# Altium schematic sheet 3A04xx_Oxocard_Mini_Science_SENSORS.SchDoc
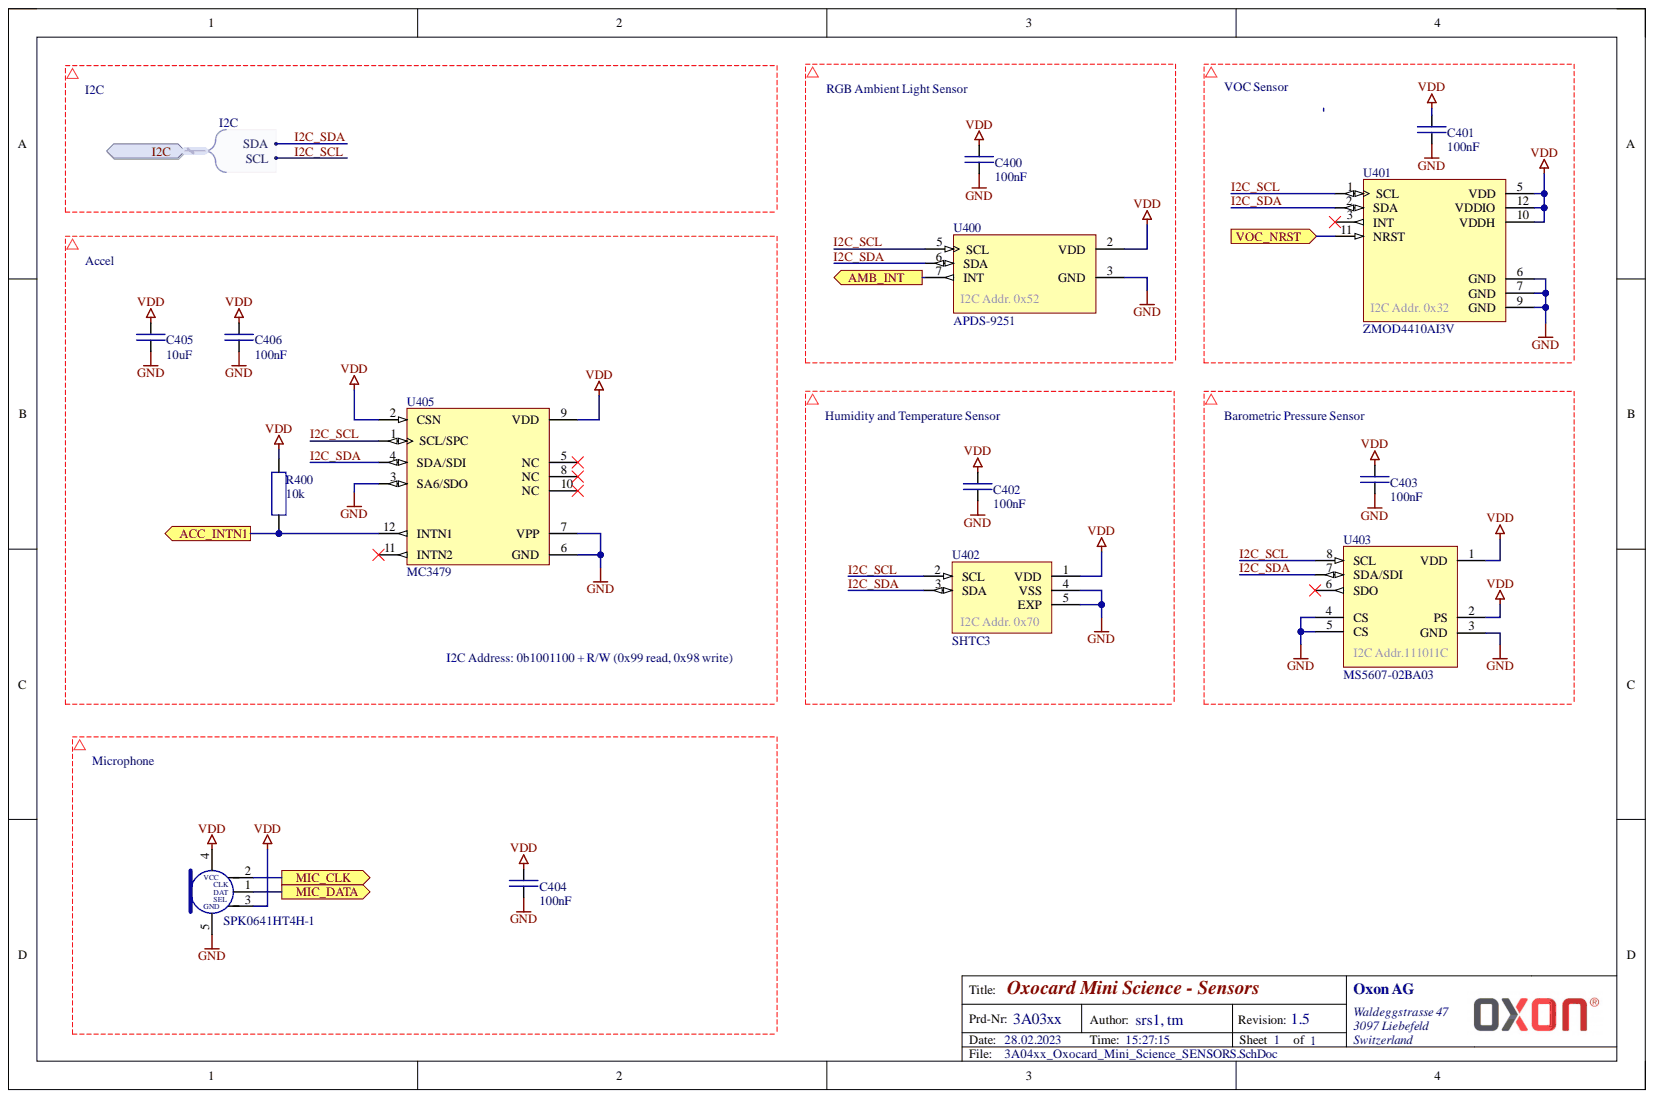
[diagram p0: 3A04xx_Oxocard_Mini_Science_SENSORS.SchDoc]

## Parts
C400  100nF  [CAPC1005X60]
C401  100nF  [CAPC1005X60]
C402  100nF  [CAPC1005X60]
C403  100nF  [CAPC1005X60]
C404  100nF  [CAPC1005X60]
C405  10uF  [CAPC1005X60]
C406  100nF  [CAPC1005X60]
R400  10k  [RESC1005X40]
SPK0641HT4H-1  Manufacturer Designator: SPK0641HT4H-1  [MIC-SPK0641HT4H-1]  (hidden comment: MK-SPK0641HT4H-1)
U400  APDS-9251  [SON50P200X65-8N]
U401  ZMOD4410AI3V  [LGA-12 3x3mm]
U402  SHTC3  [DFN170P200X75-4N]
U403  MS5607-02BA03  [SON125P300X95-8N]
U405  MC3479  [LGA-12]

## Ports
ACC_INTN1, AMB_INT, I2C, I2C.SCL, I2C.SDA, MIC_CLK, MIC_DATA, VOC_NRST

## Nets
GND: C400.1 C401.1 C402.1 C403.1 C404.1 C405.1 C406.1 SPK0641HT4H-1.5 U400.3(GND) U401.6(GND) U401.7(GND) U401.9(GND) U402.4(VSS) U402.5(EXP) U403.3(GND) U403.4(CS) U403.5(CS) U405.3(SA6/SDO) U405.6(GND) U405.7(VPP)
VDD: C400.2 C401.2 C402.2 C403.2 C404.2 C405.2 C406.2 R400.2 SPK0641HT4H-1.3(GND) SPK0641HT4H-1.4 U400.2(VDD) U401.5(VDD) U401.10(VDDH) U401.12(VDDIO) U402.1(VDD) U403.1(VDD) U403.2(PS) U405.2(CSN) U405.9(VDD)
ACC_INTN1: R400.1 U405.12(INTN1) ; port ACC_INTN1
AMB_INT: U400.7(INT) ; port AMB_INT
I2C_SCL: U400.5(SCL) U401.1(SCL) U402.2(SCL) U403.8(SCL) U405.1(SCL/SPC) ; port I2C
I2C_SDA: U400.6(SDA) U401.2(SDA) U402.3(SDA) U403.7(SDA/SDI) U405.4(SDA/SDI) ; port I2C
MIC_CLK: SPK0641HT4H-1.2(VCC) ; port MIC_CLK
MIC_DATA: SPK0641HT4H-1.1(DAT) ; port MIC_DATA
VOC_NRST: U401.11(NRST) ; port VOC_NRST

Not connected: U401 3(INT); U403 6(SDO); U405 5(NC) 8(NC) 10(NC) 11(INTN2)

## Library data of the parts
C400-C404 C406 = C-CAP0402_100n: SMD capacitor 10V 5%; Manufacturer: Wurth Electronics; Manufacturer Designator: GRM155R61A104JA1D; Component Kind: Standard; Distributor: Mouser; Distributor Part-Number: 81-GRM155R61A104JA1D; Library Name: XLib.SCHLIB
C405 = C-CAP0402_10u: SMD capacitor 6.3V 20%; Manufacturer: Wurth Electronics; Manufacturer Designator: ZRB15XR60J106ME2D; Component Kind: Standard; Distributor: Mouser; Distributor Part-Number: 81-ZRB15XR60J106ME2D; Library Name: XLib.SCHLIB
R400 = R-RES0402_10k: SMD resistor 1%; Manufacturer: Yageo; Manufacturer Designator: RC0402FR-0710KL; Component Kind: Standard; Distributor: Mouser; Distributor Part-Number: 603-RC0402FR-0710KL; Library Name: XLib.SCHLIB
SPK0641HT4H-1 = MK-SPK0641HT4H-1: MEMS-Mikrofone Top Port PDM SiSonic; Manufacturer: Knowles; Component Kind: Standard; Distributor: Mouser; Distributor Part-Number: 721-SPK0641HT4H-1; Library Name: XLib.SCHLIB
U400 = U-APDS-9251: Digital RGB Ambient Light Sensor; Manufacturer: Broadcom / Avago; Manufacturer Designator: APDS-9251-001; Component Kind: Standard; Distributor: Mouser; Distributor Part-Number: 630-APDS-9251-001; Library Name: XLib.SCHLIB
U401 = U-ZMOD4410AI3V: TVOC Sensor 1.7-3.6V; Manufacturer: Renesas / IDT; Component Kind: Standard; Distributor: Mouser; Distributor Part-Number: 972-ZMOD4410AI3V; Library Name: XLib.SCHLIB; Manufacturer Designator: ZMOD4410AI3V
U402 = U-SHTC3: Humidity and Temperature Sensor 1.62V-3.6V; Manufacturer: Sensirion; Component Kind: Standard; Distributor: Mouser; Distributor Part-Number: 403-SHTC3; Library Name: XLib.SCHLIB; Manufacturer Designator: SHTC3
U403 = U-MS5607-02BA03: Barometric Pressure Sensor; Manufacturer: Measurement Specialties; Manufacturer Designator: MS560702BA03-50; Component Kind: Standard; Distributor: Mouser; Distributor Part-Number: 824-MS560702BA03-50; Library Name: XLib.SCHLIB
U405 = U-MC3479: 3 axis accelerometer 1.7V-3.6V; Manufacturer: MEMSIC; Component Kind: Standard; Distributor: Mouser; Distributor Part-Number: 498-MC3479; Library Name: XLib.SCHLIB; Manufacturer Designator: MC3479
